# Altium schematic sheet Schematics.SchDoc
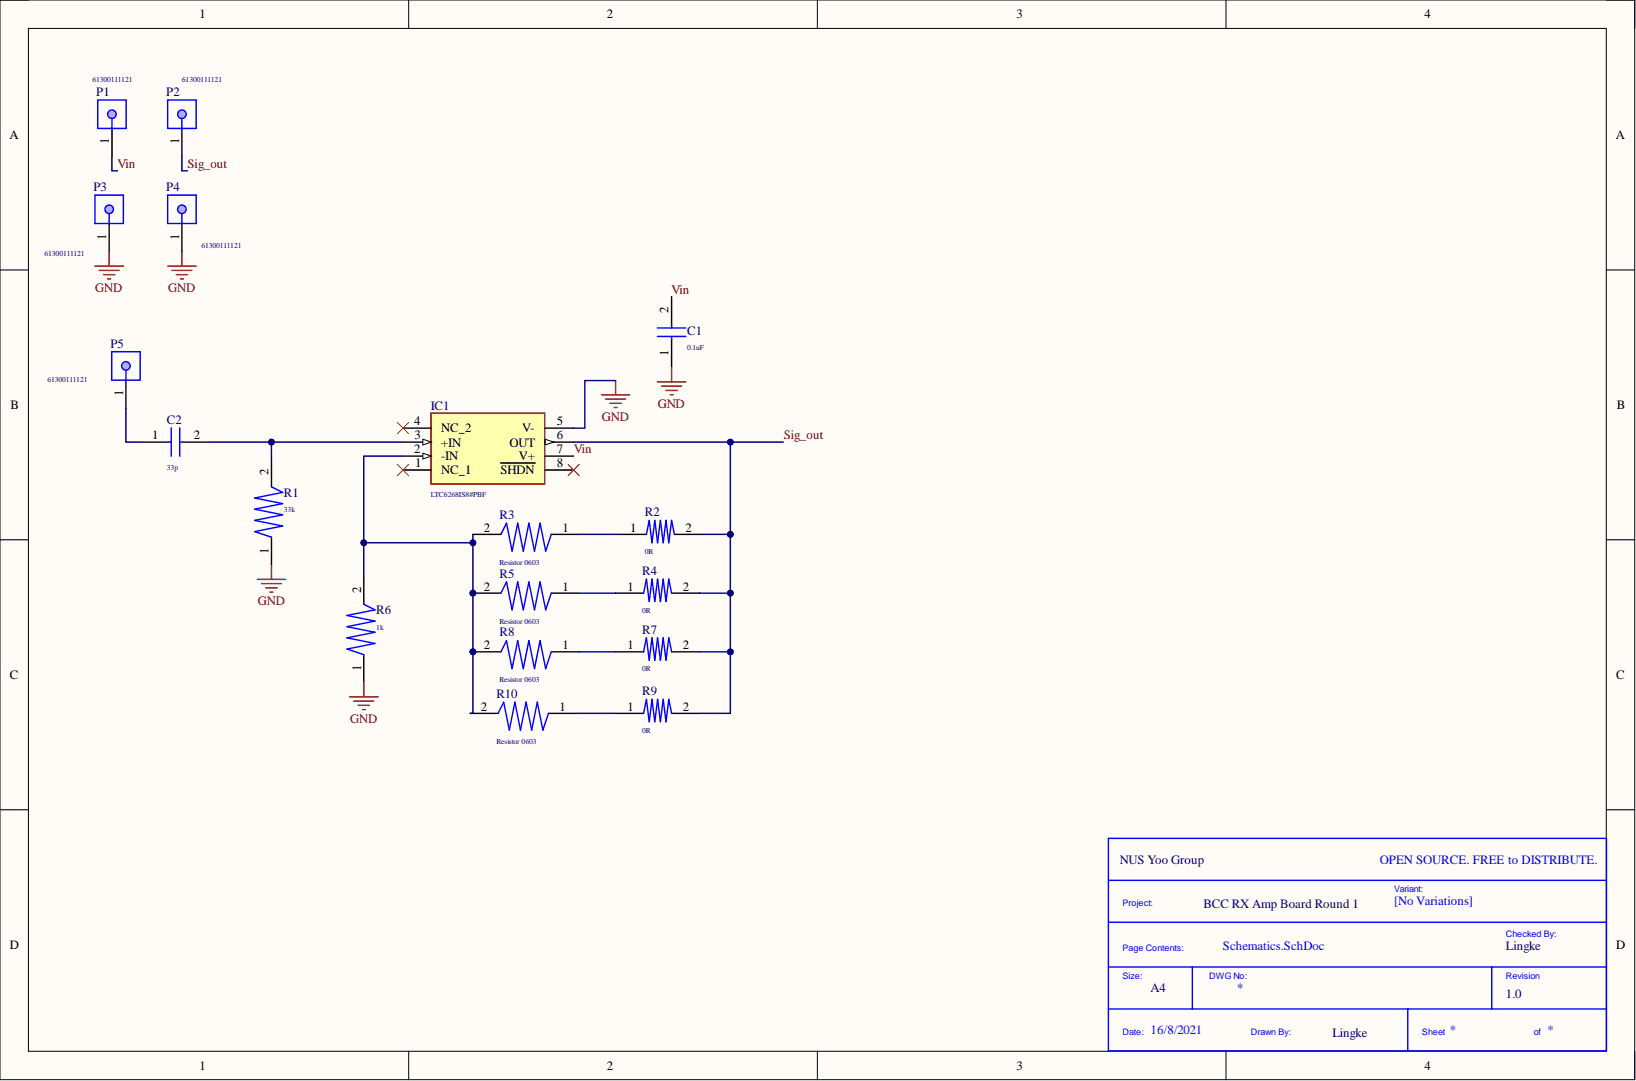
[diagram p0: Schematics.SchDoc]

## Parts
*?  Checked By: Lingke  Company: NUS Yoo Group  Project Name: BCC RX Amp Board Round 1  Revision: 1.0  Size: A4  (hidden comment: Title_Block)  (no BOM)
C1  0.1uF  [0603]
C2  33p  [0603]
IC1  LTC6268IS8#PBF  [SOIC127P599X175-8N]
P1  61300111121  [61300111121]
P2  61300111121  [61300111121]
P3  61300111121  [61300111121]
P4  61300111121  [61300111121]
P5  61300111121  [61300111121]
R1  33k  [0603]
R2  0R  [0402]
R3  Resistor 0603  [0603]
R4  0R  [0402]
R5  Resistor 0603  [0603]
R6  1k  [0603]
R7  0R  [0402]
R8  Resistor 0603  [0603]
R9  0R  [0402]
R10  Resistor 0603  [0603]

## Nets
GND: C1.1 IC1.5(V-) P3.1 P4.1 R1.1 R6.1
Sig_out: IC1.6(OUT) P2.1 R2.2 R4.2 R7.2 R9.2
Vin: C1.2 IC1.7(V+) P1.1
NetC2_1: C2.1 P5.1
NetC2_2: C2.2 IC1.3(+IN) R1.2
NetIC1_2: IC1.2(-IN) R3.2 R5.2 R6.2 R8.2 R10.2
NetR2_1: R2.1 R3.1
NetR4_1: R4.1 R5.1
NetR7_1: R7.1 R8.1
NetR9_1: R9.1 R10.1

Not connected: IC1 1(NC_1) 4(NC_2) 8(SHDN)

## Library data of the parts
*? = Title_Block: Standard Title Block.; Drawn By: Lingke; Library Name: WPT Round 2 TX.IntLib
C1 C2 = Capacitor: Component Kind: Standard; Description: Capacitor; Library Name: Symbol_lib.SchLib
IC1 = LTC6268IS8#PBF: Integrated Circuit; Manufacturer_Name: Linear Technology; Component Kind: Standard; Datasheet Link: https://componentsearchengine.com//LTC6268IS8#PBF.pdf; Height: 1.752mm; Library Name: Symbol_lib.SchLib; Manufacturer_Part_Number: LTC6268IS8#PBF; Mouser2 Part Number: 584-LTC6268IS8#PBF; Mouser2 Price/Stock: https://www.mouser.com/Search/Refine.aspx?Keyword=584-LTC6268IS8%23PBF
P1-P5 = 61300111121: WR-PHD Pin Header, THT, pitch 2.54mm, Single Row, Vertical, 1p; Manufacturer: Wurth Elektronik; Contact Resistance: 20mOhm; Pin Number: 1; Rated Current: 3A; Withstanding Voltage: 500VAC; Working Voltage: 250VAC; Component Kind: Standard; ComponentLink1Description: Manufacturer; ComponentLink1URL: http://www.we-online.de; ComponentLink2Description: Datasheet; ComponentLink2URL: https://www.we-online.com/catalog/datasheet/61300111121.pdf; Gender: Pin Header; Library Name: Altium_WR-PHD (rev20a).IntLib; Manufacturer Part Number: 61300111121; Mounting Technology: THT; Operating Temperature: -40 to +105degC; Orientation: Vertical; Packaging: Bag; Pitch: 2.54mm; Published Date: May 2020; Row Number: Single
R1 R3 R5 R6 R8 R10 = Resistor 0603: Resistor; Component Kind: Standard; Library Name: Symbol_lib.SchLib
R2 R4 R7 R9 = Resistor 0402: Component Kind: Standard; Library Name: Symbol_lib.SchLib
